# Altium schematic sheet M5CANXv1.0.SchDoc
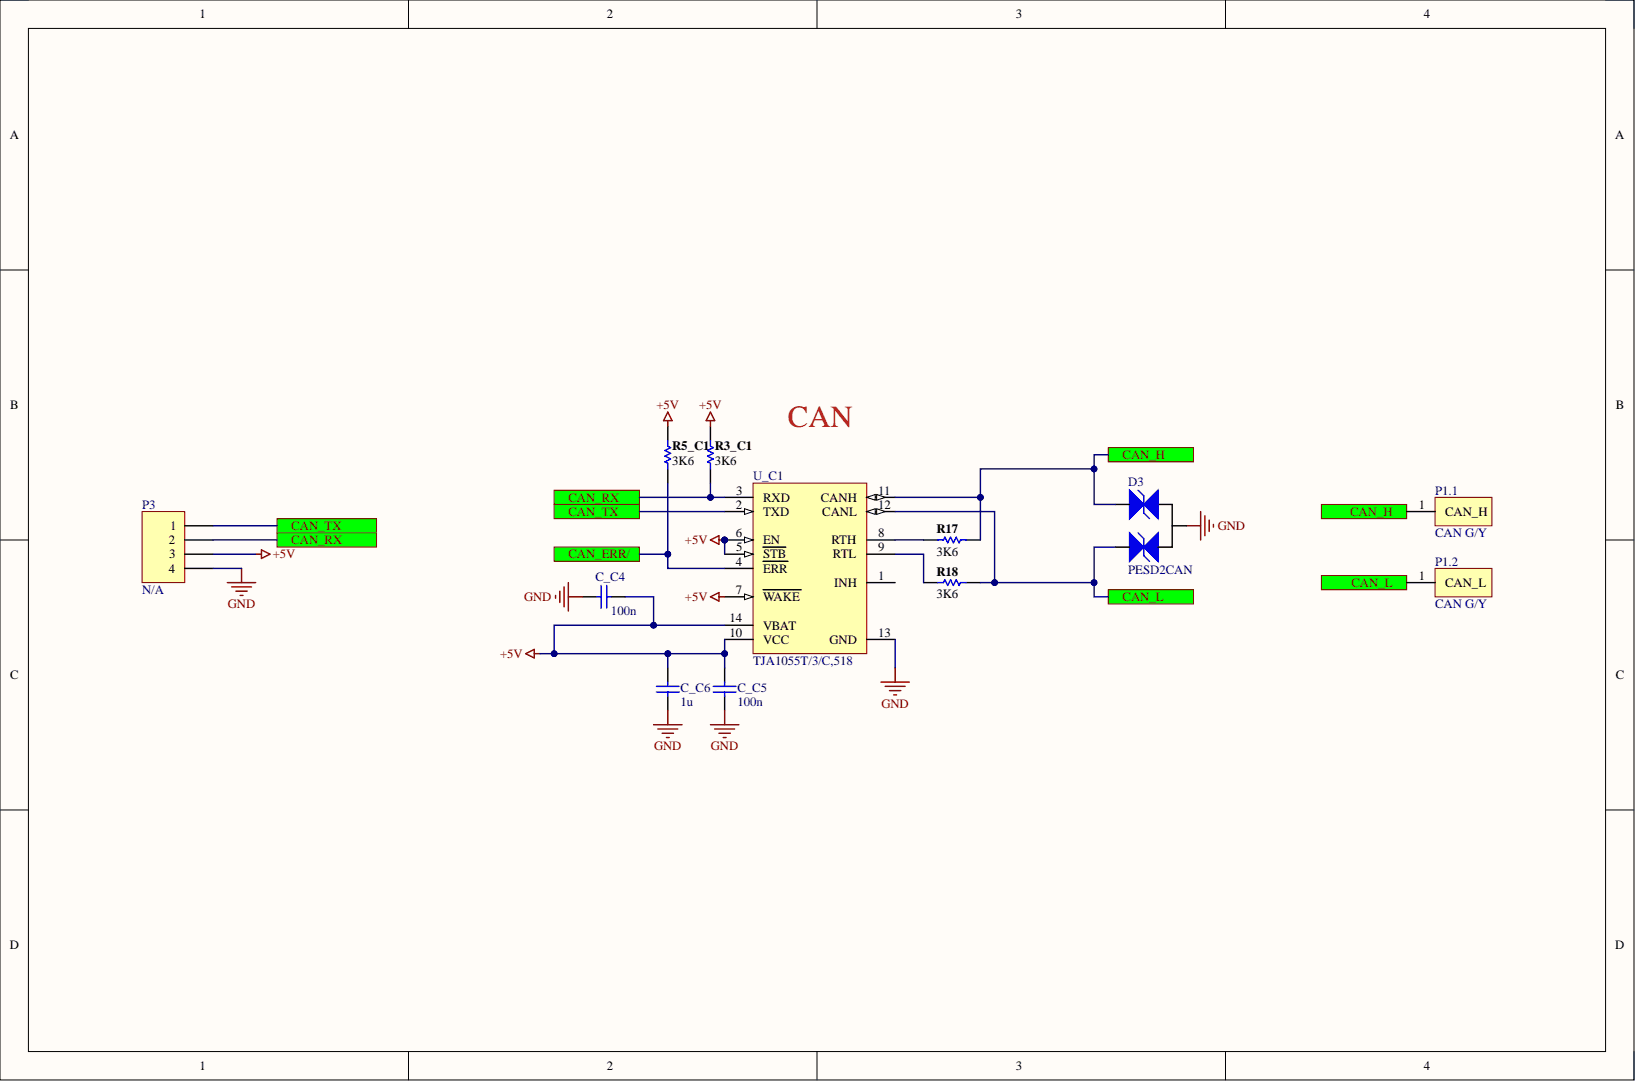
[diagram p0: M5CANXv1.0.SchDoc]

## Parts
C_C4  100n  [CAPC1608L]
C_C5  100n  [CAPC1608L]
C_C6  1u  [CAPC1608L]
D3  PESD2CAN  [SOT23_N]
P1.1  CAN G/Y  [Wago_B/R_PIN]
P1.2  CAN G/Y  [Wago_B/R_PIN]
P3  N/A  [HDR1X4_2mm]
R3_C1  3K6  [RESC1608L]
R5_C1  3K6  [RESC1608L]
R17  3K6  [RESC1608L]
R18  3K6  [RESC1608L]
U_C1  TJA1055T/3/C,518  [SOIC14_N]

## Ports
CAN_ERR/, CAN_H, CAN_L, CAN_RX, CAN_TX

## Nets
+5V: C_C4.1 C_C5.1 C_C6.1 P3.3 R3_C1.1 R5_C1.1 U_C1.5(STB) U_C1.6(EN) U_C1.7(WAKE) U_C1.10(VCC) U_C1.14(VBAT)
GND: C_C4.2 C_C5.2 C_C6.2 D3.3 P3.4 U_C1.13(GND)
CAN_ERR/: R5_C1.2 U_C1.4(ERR) ; port CAN_ERR/
CAN_H: D3.2 P1.1.1 R17.1 U_C1.11(CANH) ; port CAN_H
CAN_L: D3.1 P1.2.1 R18.1 U_C1.12(CANL) ; port CAN_L
CAN_RX: P3.2 R3_C1.2 U_C1.3(RXD) ; port CAN_RX
CAN_TX: P3.1 U_C1.2(TXD) ; port CAN_TX
NetR17_2: R17.2 U_C1.8(RTH)
NetR18_2: R18.2 U_C1.9(RTL)

Not connected: U_C1 1(INH)

## Library data of the parts
C_C4-C_C6 = Cap Semi: Capacitor Chip, 0603; Category: Capacitor; Component Kind: Standard; Library Name: Lib-USER.IntLib; Mounting Technology: Surface Mount; PackageReference: 0603
  C_C4 C_C5: Value: 100n
  C_C6: Value: 1u
D3 = ESD_CAN: Diode TVS, Dual, Bidir; Category: Diode TVS Arrays; Component Kind: Standard; Library Name: Lib-USER.IntLib; Mounting Technology: Surface Mount; PackageReference: SOT23_N; Value: PESD2CAN
P1.1 P1.2 = WAGO_KNX_B/R: Connector, WAGO, 1PIN, 243-131; Category: Connector KNX; Component Kind: Standard; Library Name: Lib-USER.IntLib; Mounting Technology: Thru-Hole; Value: 243-131
  P1.1: PackageReference: WAGO_Yellow
  P1.2: PackageReference: WAGO_Gray
P3 = Header 4X1: Header, 4-Pin; Category: Header; Component Kind: Standard; Library Name: Lib-Connectors.IntLib; Mounting Technology: Thru-Hole; Value: N/A
R3_C1 R5_C1 R17 R18 = Res Semi: Resistor, 1% 0603 (1608); Tolerance: 5%; Category: Resistor; Component Kind: Standard; Library Name: Lib-USER.IntLib; Mounting Technology: Surface Mount; PackageReference: 0603; Value: 3K6
U_C1 = CAN_FT: IC, Transceiver CAN_FT; Category: Transceiver CAN_FT; Component Kind: Standard; Library Name: Lib-USER.IntLib; Mounting Technology: Surface Mount; PackageReference: SOIC14; Value: TJA1055T/3/C,518
